# Altium schematic sheet Sensor-Sheet1.SchDoc(Sensor-Sheet1)
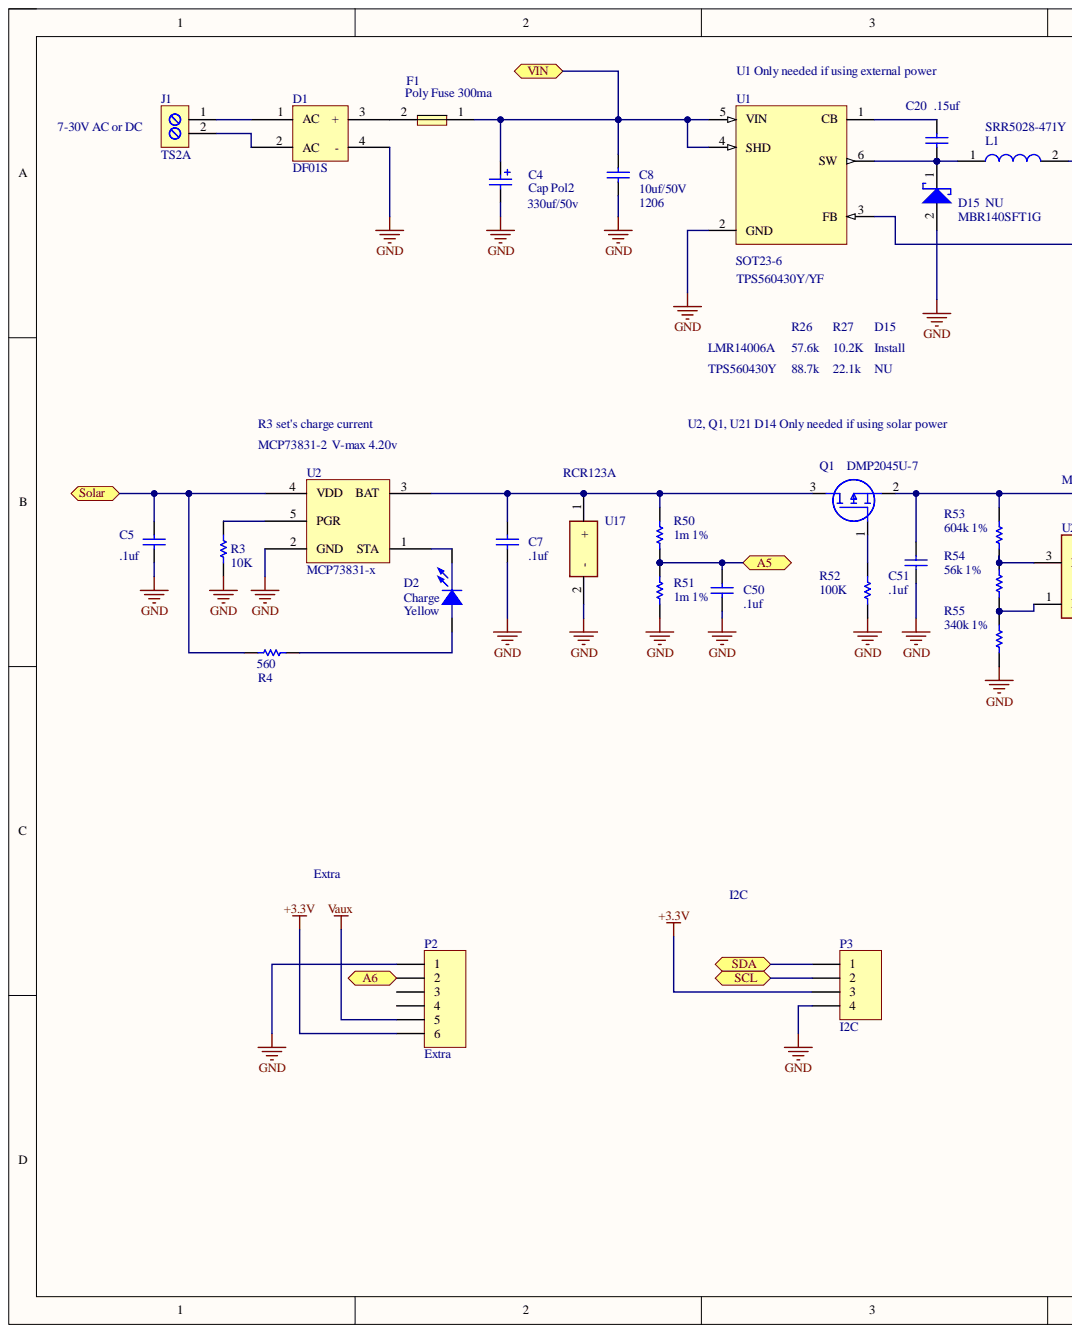
[diagram p0: Sensor-Sheet1.SchDoc, left]
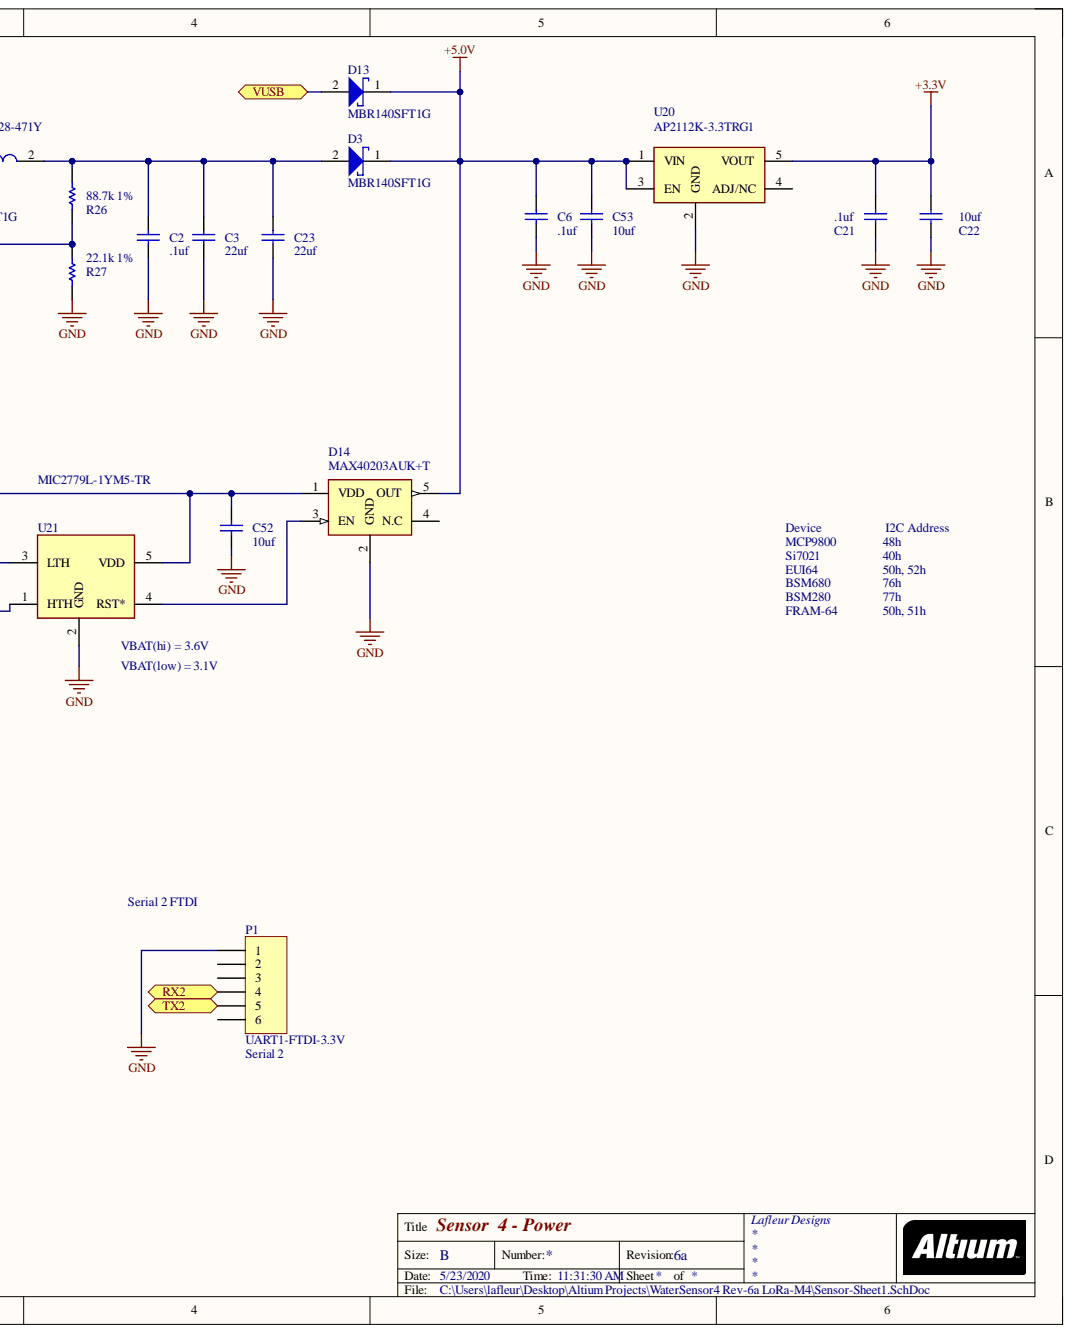
[diagram p1: Sensor-Sheet1.SchDoc, right]

## Parts
C2  .1uf  [c0805]
C3  22uf  [C0805]
C4  Cap Pol2  value 330uf/50v  [Capacitor 12x5x18]
C5  .1uf  [c0805]
C6  .1uf  [c0805]
C7  .1uf  [C0805]
C8  10uf/50V  [C1210]
C20  .15uf  [c0805]
C21  .1uf  [c0805]
C22  10uf  [C0805]
C23  22uf  [C0805]
C50  .1uf  [c0805]
C51  .1uf  [c0805]
C52  10uf  [c0805]
C53  10uf  [C0805]
D1  DF01S  [SOP4-Bridge]
D2  Charge  [LED 0805]
D3  MBR140SFT1G  [SOD123FL-2]
D13  MBR140SFT1G  [SOD123FL-2]
D14  MAX40203AUK+T  [SOT95P280X145-5N]
D15  MBR140SFT1G  [SOD123FL-2]
F1  Poly Fuse 300ma  [PTC 2016L]
J1  TS2A  [TS3.81X2]
L1  SRR5028-471Y  [INDPM5858X300N]
P1  UART1-FTDI-3.3V  [HDR1X6]
P2  Extra  [HDR1X6]
P3  I2C  [HDR1X4]
Q1  DMP2045U-7  [SOT96P240X110-3N]
R3  10K  [6-0805]
R4  560  [6-0805]
R26  88.7k 1%  [6-0805]
R27  22.1k 1%  [6-0805]
R50  1m 1%  [6-0805]
R51  1m 1%  [6-0805]
R52  100K  [6-0805]
R53  604k 1%  [6-0805]
R54  56k 1%  [6-0805]
R55  340k 1%  [6-0805]
U1  SOT23-6  [sot23-6]
U2  MCP73831-x  [SOT-23-OT5_L]
U17  RCR123A  [1051]
U20  AP2112K-3.3TRG1  [SOT95P282X130-5N]
U21  MIC2779L-1YM5-TR  [SOT95P280X145-5N]

## Ports
A5, A6, RX2, SCL, SDA, Solar, TX2, VIN, VUSB

## Nets
+3.3V: C21.1 C22.1 P2.6 P3.3 U20.5(VOUT)
+5.0V: C6.1 C53.1 D3.1 D13.1 D14.5(OUT) U20.1(VIN) U20.3(EN)
GND: C2.2 C3.2 C4.1 C5.2 C6.2 C7.2 C8.2 C21.2 C22.2 C23.2 C50.2 C51.2 C52.2 C53.2 D1.4(-) D14.2(GND) D15.2 P1.1 P2.1 P3.4 R3.1 R27.2 R51.2 R52.2 R55.2 U1.2(GND) U2.2(GND) U17.2 U20.2(GND) U21.2(GND)
Vaux: P2.5
A5: C50.1 R50.2 R51.1 ; port A5
A6: P2.2 ; port A6
RX2: P1.4 ; port RX2
SCL: P3.2 ; port SCL
SDA: P3.1 ; port SDA
Solar: C5.1 R4.2 U2.4(VDD) ; port Solar
TX2: P1.5 ; port TX2
VIN: C4.2 C8.1 F1.1 U1.4(SHD) U1.5(VIN) ; port VIN
VUSB: D13.2 ; port VUSB
NetC2_1: C2.1 C3.1 C23.1 D3.2 L1.2 R26.1
NetC7_1: C7.1 Q1.3 R50.1 U2.3(BAT) U17.1
NetC20_1: C20.1 U1.1(CB)
NetC20_2: C20.2 D15.1 L1.1 U1.6(SW)
NetC51_1: C51.1 C52.1 D14.1(VDD) Q1.2 R53.1 U21.5(VDD)
NetD1_1: D1.1(AC) J1.1
NetD1_2: D1.2(AC) J1.2
NetD1_3: D1.3(+) F1.2
NetD2_1: D2.1 R4.1
NetD2_2: D2.2 U2.1(STA)
NetD14_3: D14.3(EN) U21.4(RST*)
NetD14_4: D14.4(N.C)
NetP1_2: P1.2
NetP1_3: P1.3
NetP1_6: P1.6
NetP2_3: P2.3
NetP2_4: P2.4
NetQ1_1: Q1.1 R52.1
NetR3_2: R3.2 U2.5(PGR)
NetR26_2: R26.2 R27.1 U1.3(FB)
NetR53_2: R53.2 R54.1 U21.3(LTH)
NetR54_2: R54.2 R55.1 U21.1(HTH)
NetU20_4: U20.4(ADJ/NC)

## Library data of the parts
C2 C3 C5-C8 C20 C23 C50-C53 = CAP_1: Capacitor; Fitted: True; Component Kind: Standard; Library Name: N2K Project.SCHLIB
C4 = Cap Pol2: Polarized Capacitor (Axial); Component Kind: Standard; LatestRevisionDate: 17-Jul-2002; LatestRevisionNote: Re-released for DXP Platform.; Library Name: Miscellaneous Devices.IntLib; PackageDescription: Polarized Capacitor; 2 Leads; PackageReference: POLAR0.8; Published: 8-Jun-2000; Signal Integrity: Cap Pol; Simulation: CAP; Publisher: Altium Limited
C21 C22 = CAP_2: Capacitor; Fitted: True; Component Kind: Standard; Library Name: Winmor-Demo-R2.IntLib
D1 = Bridge DF01S: Component Kind: Standard; Library Name: WaterSensor.SCHLIB
D2 = LTST150: Part Description: SMT LED Green; Vendor Part Number: LTST-C150GKRT; DEVICE: C150; Flex_PN: D20000; Vendor: LITEON; Component Kind: Standard; Library Name: Winmor-Demo-R1.IntLib
D3 D13 D15 = Diode Schottky: Schottky Diode; Component Kind: Standard; DatasheetDocument: RevB, 21-Aug-2003; Published: 5-Jan-2004; Library Name: Winmor-Demo-R2.IntLib; Publisher: Altium Limited
D14 = MAX40203AUK+T: Diode; Manufacturer_Name: Maxim Integrated; Component Kind: Standard; Datasheet Link: http://www.mouser.com/datasheet/2/256/MAX40203-1517812.pdf; Height: 1.45mm; Library Name: WaterSensor V4-6_6.SCHLIB; Manufacturer_Part_Number: MAX40203AUK+T; Mouser Part Number: 700-MAX40203AUK+T; Mouser Price/Stock: https://www.mouser.com/Search/Refine.aspx?Keyword=700-MAX40203AUK%2BT
F1 = Poly Fuse: Component Kind: Standard; Description: Poly Fuse; Library Name: N2K Project.SCHLIB
J1 = TS2A: Screw Terminal, 3.81mm (.15in) 2X; Component Kind: Standard; Library Name: WaterSensor_1.SCHLIB
L1 = SRR5028-221Y: Inductor; Manufacturer_Name: Bourns; Component Kind: Standard; Datasheet Link: http://docs-emea.rs-online.com/webdocs/0f2b/0900766b80f2b793.pdf; Height: 3mm; Manufacturer_Part_Number: SRR5028-221Y; Mouser Part Number: 652-SRR5028-221Y; Mouser Price/Stock: https://www.mouser.com/Search/Refine.aspx?Keyword=652-SRR5028-221Y; RS Part Number: 7272063P; RS Price/Stock: http://uk.rs-online.com/web/p/products/7272063P
P1 P2 = Header 6: Header, 6-Pin; Component Kind: Standard; LatestRevisionDate: 17-Jul-2002; LatestRevisionNote: Re-released for DXP Platform.; Signal Integrity: Connector; Library Name: BG-Barometric-Sensor Rev5 802.SCHLIB; Publisher: Altium Limited
P3 = Header 4: Header, 4-Pin; Component Kind: Standard; LatestRevisionDate: 17-Jul-2002; LatestRevisionNote: Re-released for DXP Platform.; Library Name: Miscellaneous Connectors.IntLib; Signal Integrity: Connector; Publisher: Altium Limited
Q1 = DMP2045U-7: MOSFET (P-Channel); Manufacturer_Name: Diodes Inc.; Component Kind: Standard; Datasheet Link: https://www.diodes.com/assets/Datasheets/DMP2045U.pdf; Height: 1.1mm; Manufacturer_Part_Number: DMP2045U-7; Mouser Part Number: 621-DMP2045U-7; Mouser Price/Stock: https://www.mouser.com/Search/Refine.aspx?Keyword=621-DMP2045U-7; RS Part Number: 1827098; RS Price/Stock: https://uk.rs-online.com/web/p/products/1827098
R3 R4 R26 R27 R50-R55 = ERJ8EN: Precision Thick Film Chip Resistor, 10 Ohm to 2.2M Ohm Range, 1% Tolerance, 1206 Size, 0.125 W; Code_IPC: 3216 [1206]; Component Kind: Standard; ComponentLink1Description: Manufacturer Link; ComponentLink1URL: http://www.panasonic.com/industrial/components/resistive_products.htm; ComponentLink2Description: Datasheet; ComponentLink2URL: http://www.panasonic.com/industrial/components/pdf/AOA0000CE2.pdf; LatestRevisionDate: 19-Mar-2004; LatestRevisionNote: Silkscreen size reduced.; PackageDocument: Mar-2003; PackageReference: 8-1206; Published: 17-Dec-2003; Signal Integrity: Res; Simulation: RESISTOR; Publisher: Altium Limited
  R3 R4: Library Name: N2K Project.SCHLIB
  R26 R27 R50-R55: Library Name: Panasonic Resistor.IntLib
U1 = LM2842: .6A SIMPLE SWITCHER® Step-Down Voltage Regulator SOT23-6; Manufacturer: National Semiconductor; PackageTopMarking: NSXYTT*L22670*5.0; PowerWise: N; Code_JEDEC: MS-012-AA; Component Kind: Standard; ComponentLink1Description: LM22670 Datasheet; ComponentLink1URL: http://www.national.com/ds/LM/LM22670.pdf; ComponentLink2Description: LM22670 Web Page; ComponentLink2URL: http://www.national.com/pf/LM/LM22670.html; ComponentLink3Description: MRA08B Package Page; ComponentLink3URL: http://www.national.com/packaging/folders/mra08b.html; CompType: Switcher; DatasheetVersion: Jan-2011; Library Name: WaterSensor 2_7.SCHLIB; PackageDescription: Molded, PSOP .193 x .154 x .058in, 8 Lead, .050in Pitch, Large DAP; PackageReference: MRA08B; PackageVersion: revB, Dec-2007; PinCount: 8; RevisionNote: DatasheetVersion Updated. Component URLs added; RoHS: Y
U2 = MCP7381: MCP73831 Li-Ion, Li-Polymer Charger; Component Kind: Standard; Library Name: WaterSensor_5.SCHLIB
U17 = 1051: Undefined or Miscellaneous; Manufacturer_Name: Keystone Electronics; Allied_Number: 71135178; Allied Price/Stock: https://www.alliedelec.com/keystoneelectronics-1051/71135178/; Component Kind: Standard; Datasheet Link: https://www.keyelco.com/product-pdf.cfm?p=5205; Height: 15.24mm; Manufacturer_Part_Number: 1051; Mouser Part Number: 534-1051; Mouser Price/Stock: https://www.mouser.com/Search/Refine.aspx?Keyword=534-1051
U20 = AP2112K-3.3TRG1: Integrated Circuit; Manufacturer_Name: Diodes Inc.; Allied_Number: 70550983; Allied Price/Stock: https://www.alliedelec.com/diodes-inc-ap2112k-3-3trg1/70550983/; Component Kind: Standard; Datasheet Link: http://uk.rs-online.com/web/p/products/8283509; Height: 1.3mm; Library Name: WaterSensor V4-6_7.SCHLIB; Manufacturer_Part_Number: AP2112K-3.3TRG1; Mouser Part Number: 621-AP2112K-3.3TRG1; Mouser Price/Stock: https://www.mouser.com/Search/Refine.aspx?Keyword=621-AP2112K-3.3TRG1; RS Part Number: 8283509P; RS Price/Stock: http://uk.rs-online.com/web/p/products/8283509P
U21 = MIC2779-1YM5-TR: Integrated Circuit; Manufacturer_Name: Microchip; Manufacturer_Part_Number: MIC2778-1YM5-TR; Component Kind: Standard; Datasheet Link: http://www.microchip.com/mymicrochip/filehandler.aspx?ddocname=en580465; Height: 1.45mm; Library Name: WaterSensor V4-6a.SCHLIB; Mouser Part Number: 998-MIC2778-1YM5TR; Mouser Price/Stock: https://www.mouser.com/Search/Refine.aspx?Keyword=998-MIC2778-1YM5TR
